# Altium schematic sheet CH340N.SchDoc(CH340N)
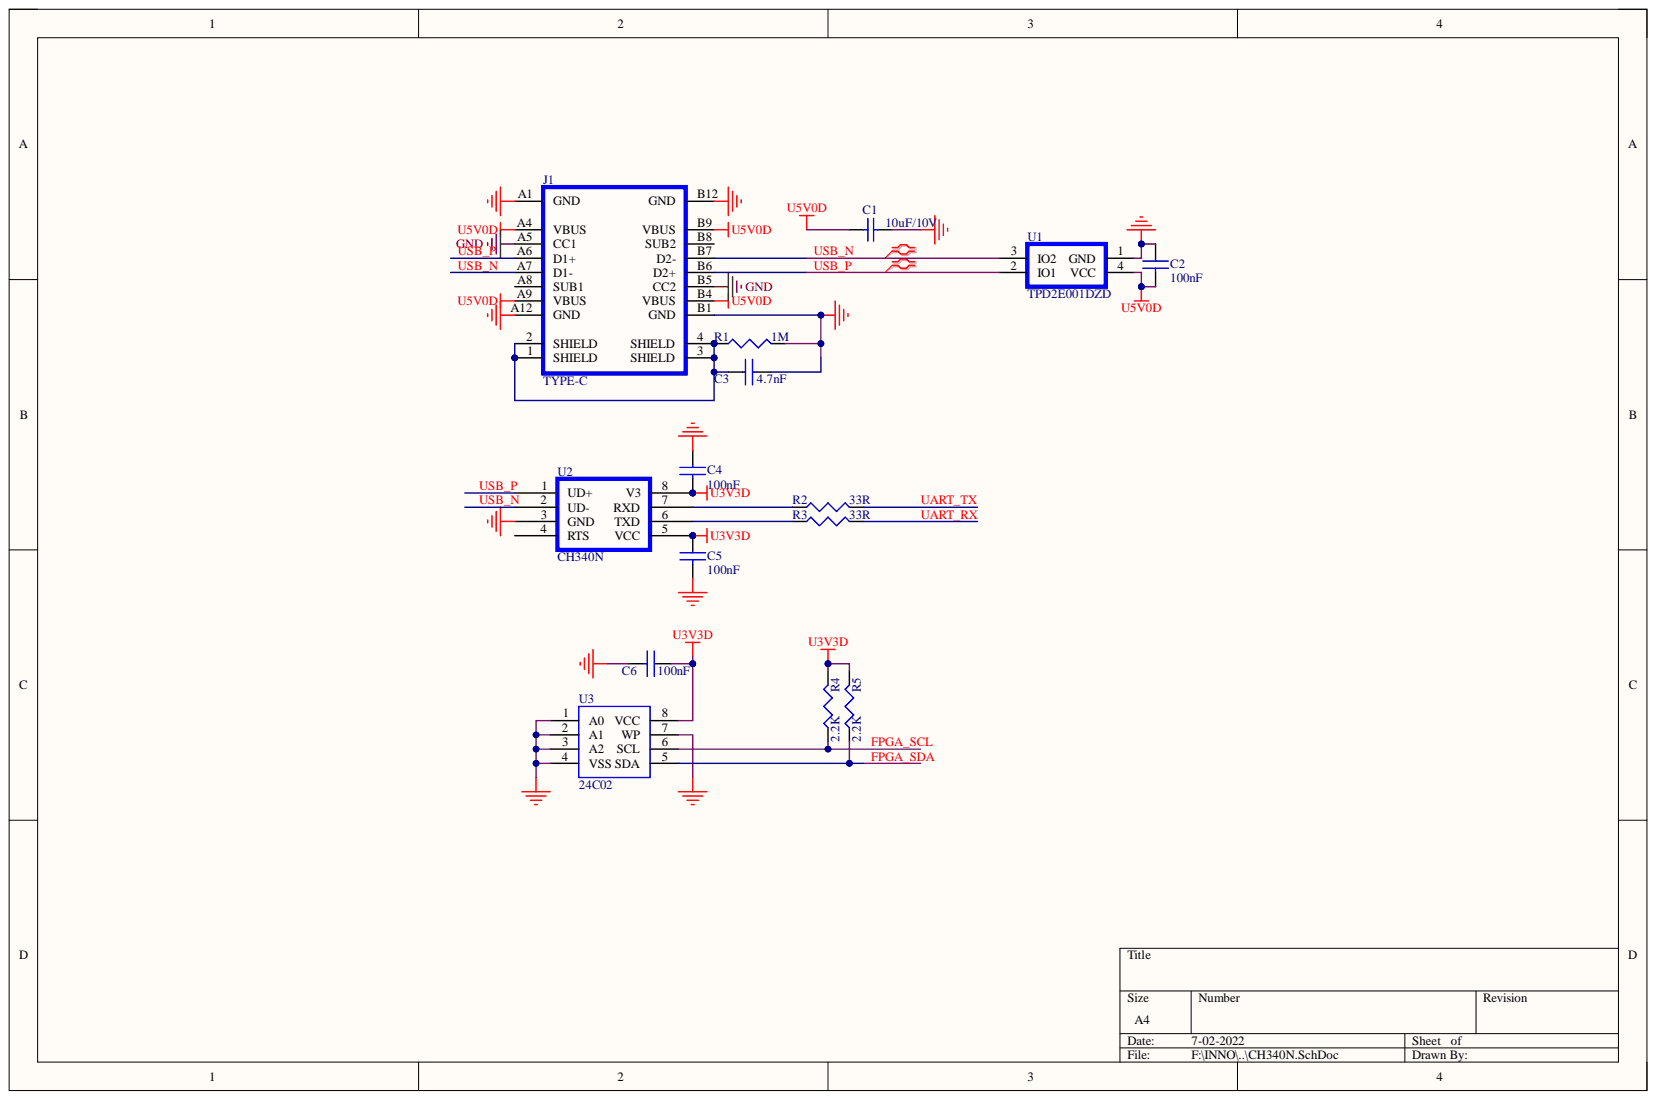
[diagram p0: CH340N.SchDoc]

## Parts
C1  10uF/10V  [0805-C]
C2  100nF  [0402-C]
C3  4.7nF  [0402-C]
C4  100nF  [0402-C]
C5  100nF  [0402-C]
C6  100nF  [0402-C]
J1  TYPE-C  [USB_TYPE-C]
R1  1M  [0402-R]
R2  33R  [0402-R]
R3  33R  [0402-R]
R4  2.2K  [0402-R]
R5  2.2K  [0402-R]
U1  TPD2E001DZD  [DZD]
U2  CH340N  [SOP8_N]
U3  24C02  [SOP8_N]

## Nets
GND: C1.2 C2.1 C3.2 C4.1 C5.2 C6.2 J1.A1(GND) J1.A5(CC1) J1.A12(GND) J1.B1(GND) J1.B5(CC2) J1.B12(GND) R1.2 U1.1(GND) U2.3(GND) U3.1(A0) U3.2(A1) U3.3(A2) U3.4(VSS) U3.7(WP)
U3V3D: C4.2 C5.1 C6.1 R4.2 R5.2 U2.5(VCC) U2.8(V3) U3.8(VCC)
U5V0D: C1.1 C2.2 J1.A4(VBUS) J1.A9(VBUS) J1.B4(VBUS) J1.B9(VBUS) U1.4(VCC)
FPGA_SCL: R4.1 U3.6(SCL)
FPGA_SDA: R5.1 U3.5(SDA)
UART_RX: R3.2
UART_TX: R2.2
USB_N: J1.A7(D1-) J1.B7(D2-) U1.3(IO2) U2.2(UD-)
USB_P: J1.A6(D1+) J1.B6(D2+) U1.2(IO1) U2.1(UD+)
NetC3_1: C3.1 J1.1(SHIELD) J1.2(SHIELD) J1.3(SHIELD) J1.4(SHIELD) R1.1
NetR2_1: R2.1 U2.7(RXD)
NetR3_1: R3.1 U2.6(TXD)

Not connected: J1 A8(SUB1) B8(SUB2); U2 4(RTS)

## Library data of the parts
C1 = Cap Semi: Capacitor (Semiconductor SIM Model); Component Kind: Standard; Library Name: ctr_prj.SchLib
C2-C6 = CAP NP: Ceramics capacitors; Component Kind: Standard; Library Name: Schlib.SchLib
J1 = TYPE-C: USB3.0 TYPE-C; Component Kind: Standard; Library Name: YuanQi.SchLib
R1-R5 = Res: Resistor; Component Kind: Standard; Library Name: Schlib.SchLib
U1 = TPD2E001: ESD Protection; Component Kind: Standard; Library Name: Schlib.SchLib
U3 = CAT24C256: EEPROM; Component Kind: Standard; Library Name: Schlib.SchLib
U2 = CH340N: Component Kind: Standard; Library Name: YuanQi.SchLib
